# Altium schematic sheet Pitch CV & Tune Depth.SchDoc("Pitch CV & Tune Depth")
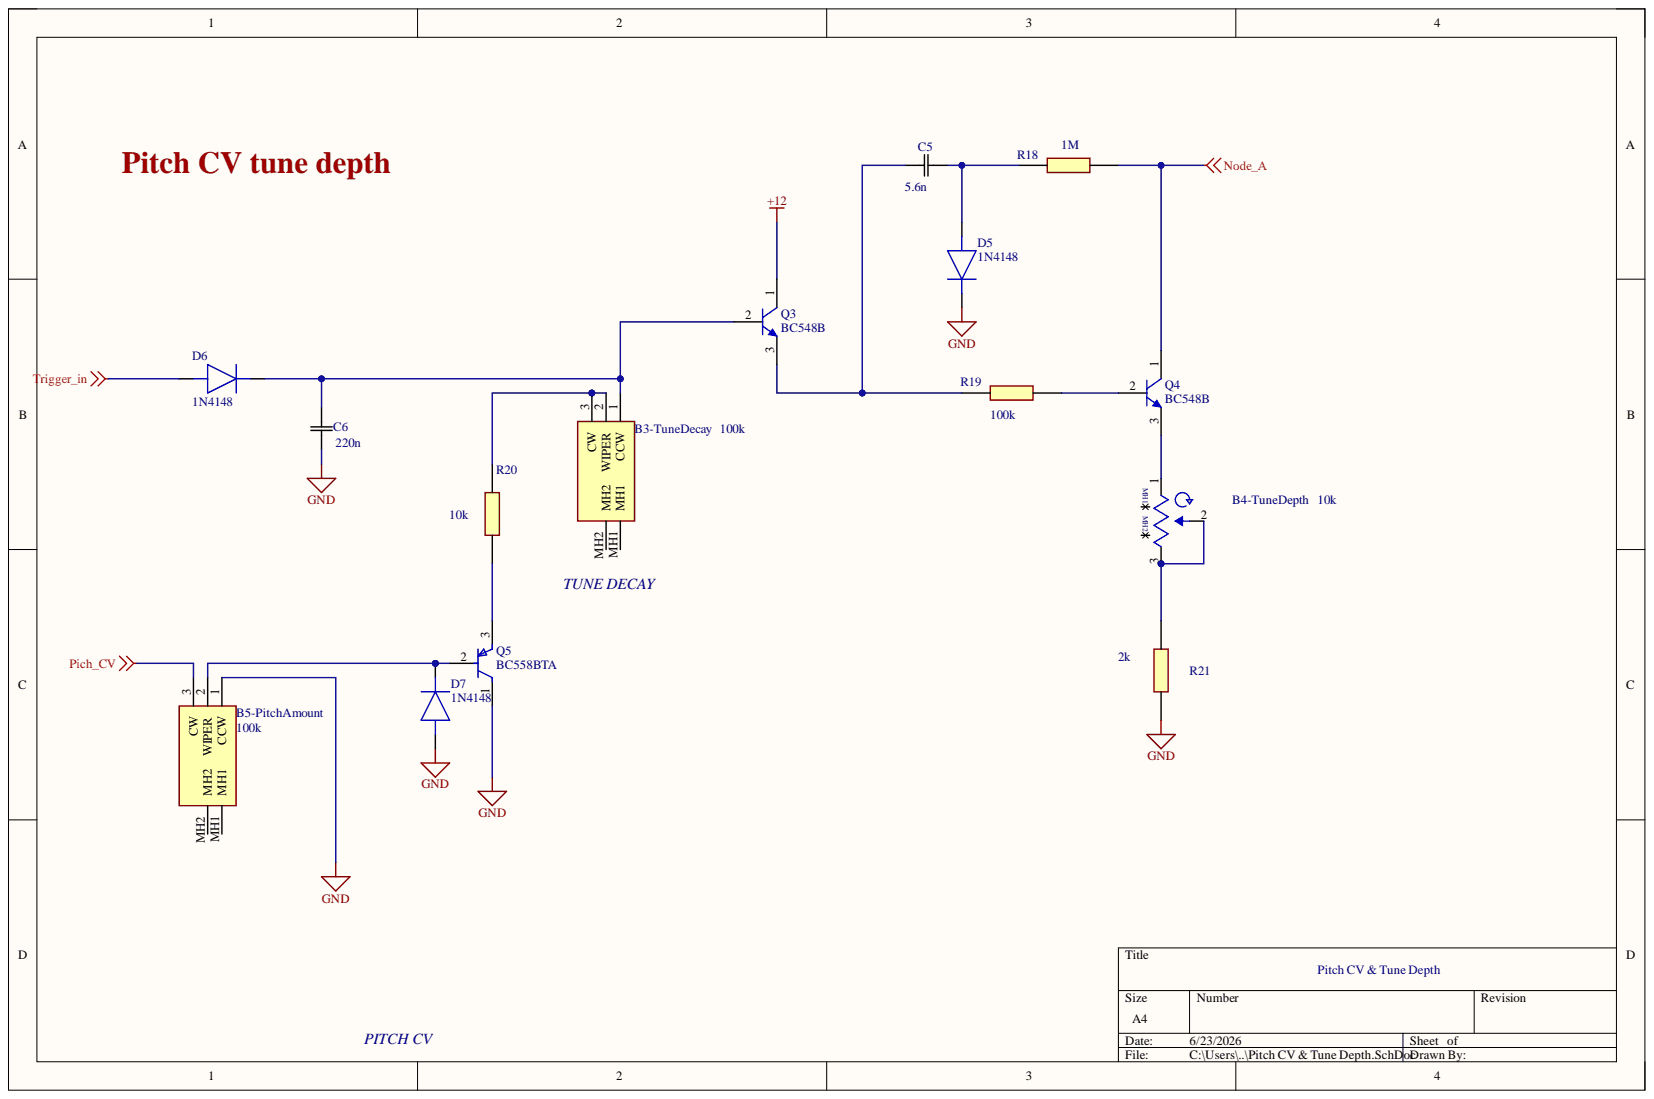
[diagram p0: Pitch CV & Tune Depth.SchDoc]

## Parts
B3-TuneDecay  value 100k  [PTV09A4025FB104]  (hidden comment: PTV09A-4025F-B104)
B4-TuneDepth  value 10k  [PTV09A4025FB103]  (hidden comment: PTV09A-4025F-B103)
B5-PitchAmount  value 100k  [PTV09A4025FB104]  (hidden comment: PTV09A-4025F-B104)
C5  value 5.6n  [CAP_MMK5_122_J100_KEM]  (hidden comment: MMK5332K50J01L4BULK)
C6  value 220n  [CAP_MMK5_122_J100_KEM-1]  (hidden comment: MMK5224J50J01L4BULK)
D5  1N4148  [DIOAD1068W53L380D172]
D6  1N4148  [DIOAD1068W53L380D172]
D7  1N4148  [DIOAD1068W53L380D172]
Q3  BC548B  [ONSC-TO-92-3-29-11]
Q4  BC548B  [ONSC-TO-92-3-29-11]
Q5  BC558BTA  [FP-135AR-MFG]
R18  value 1M  [RESAD1590W60L630D240]  (hidden comment: MFR-25FTF52-600K)
R19  value 100k  [RESAD1590W60L630D240]  (hidden comment: MFR-25FTF52-600K)
R20  value 10k  [RESAD1590W60L630D240]  (hidden comment: MFR-25FTF52-600K)
R21  value 2k  [RESAD1590W60L630D240]  (hidden comment: MFR-25FTF52-600K)

## Nets
+12: Q3.1
GND: B5-PitchAmount.1(CCW) C6.1 D5.1 D7.2 Q5.1 R21.2
Node_A: Q4.1 R18.2
Pich_CV: B5-PitchAmount.3(CW)
Trigger_in: D6.2
NetB3-TuneDecay_1: B3-TuneDecay.1(CCW) C6.2 D6.1 Q3.2
NetB3-TuneDecay_2: B3-TuneDecay.2(WIPER) B3-TuneDecay.3(CW) R20.1
NetB4-TuneDepth_1: B4-TuneDepth.1 Q4.3
NetB4-TuneDepth_2: B4-TuneDepth.2 B4-TuneDepth.3 R21.1
NetB5-PitchAmount_2: B5-PitchAmount.2(WIPER) D7.1 Q5.2
NetC5_1: C5.1 Q3.3 R19.1
NetC5_2: C5.2 D5.2 R18.1
NetQ4_2: Q4.2 R19.2
NetQ5_3: Q5.3 R20.2

Not connected: B3-TuneDecay MH1 MH2; B4-TuneDepth 4 5; B5-PitchAmount MH1 MH2

## Library data of the parts
B3-TuneDecay B5-PitchAmount = CMP-032-000030-1: Variable Resistor; Manufacturer_Name: Bourns; Arrow Part Number: PTV09A-4025F-B104; Arrow Price/Stock: https://www.arrow.com/en/products/ptv09a-4025f-b104/bourns?region=nac; Component Kind: Standard; Datasheet Link: https://www.digikey.ph/en/products/detail/bourns-inc/PTV09A-4025F-B104/3820577?msockid=39f1ec84d77b6f4428b7f904d6676eed; Design Item ID: PTV09A-4025F-B104; Height: 25.5mm; Manufacturer_Part_Number: PTV09A-4025F-B104; Mouser Part Number: 652-PTV09A4025FB104; Mouser Price/Stock: https://www.mouser.co.uk/ProductDetail/Bourns/PTV09A-4025F-B104?qs=fgHA1UgJI8DoC1GZkaXClg%3D%3D; Source: PTV0910k.SchLib; Library Name: PoliTeK
B4-TuneDepth = CMP-011-000000-2: Variable Resistor; Manufacturer_Name: Bourns; Arrow Part Number: PTV09A-4025F-B103; Arrow Price/Stock: null?region=nac; Component Kind: Standard; Component Type: Standard; Datasheet Link: https://www.bourns.com/docs/Product-Datasheets/PTV09.pdf; Design Item ID: PTV09A-4025F-B103; Height: 25.5mm; Manufacturer_Part_Number: PTV09A-4025F-B103; Mouser Part Number: 652-PTV09A-4025FB103; Mouser Price/Stock: https://www.mouser.co.uk/ProductDetail/Bourns/PTV09A-4025F-B103?qs=okKRLHKnSlbwMbAO07mw0g%3D%3D; Pin Count: 5; Source: PTV0910k.SchLib; Library Name: EMEA - Politecnico di Torino - PoliTek Music
C5 = CMP-001-00099-1: 3.3 nF ceramic capacitor-; Component Kind: Standard; Component Type: Standard; Design Item ID: MMK5332K50J01L4BULK; Pins: 2; Source: MMK5332K50J01L4BULK.SchLib; Library Name: EMEA - Politecnico di Torino - PoliTek Music
C6 = CMP-001-00098-2: 220 nF film capacitor; Component Kind: Standard; Component Type: Standard; Design Item ID: MMK5224J50J01L4BULK; Pins: 2; Source: MMK5224J50J01L4BULK.SchLib; Library Name: EMEA - Politecnico di Torino - PoliTek Music
D5-D7 = CMP-004-00032-1: Small Signal Diode; Manufacturer_Name: onsemi; Arrow Part Number: 1N4148; Arrow Price/Stock: https://www.arrow.com/en/products/1n4148/on-semiconductor?region=europe; Component Kind: Standard; Component Type: Standard; Datasheet Link: https://www.onsemi.com/pub/Collateral/1N914-D.PDF; Design Item ID: 1N4148; Manufacturer_Part_Number: 1N4148; Mouser Part Number: 512-1N4148; Mouser Price/Stock: https://www.mouser.co.uk/ProductDetail/onsemi-Fairchild/1N4148?qs=i4Fj9T%2FoRm8RMUhj5DeFQg%3D%3D; Pins: 2; Source: SamacSys.SchLib; Library Name: EMEA - Politecnico di Torino - PoliTek Music
Q3 Q4 = CMP-013-00036-5: Bulk Through Hole NPN Single Bipolar (BJT) Transistor 200 @ 2mA 5V 100mA 500mW 300MHz; Case/Package: TO-92; Component Kind: Standard; Frequency: 300MHz; Max Operating Temperature: 150°C; Min Operating Temperature: -55°C; Mounting Technology: Through Hole; Pins: 3; Library Name: EMEA - Politecnico di Torino - PoliTek Music
Q5 = CMP-00278-84408946-1: BC558BTA Small Signal Bipolar Transistor, 0.1A I(C), 30V V(BR)CEO, 1-Element, PNP, Silicon, TO-92; Collector Base Voltage (VCBO): -30V; Collector Emitter Saturation Voltage: -250mV; Current Rating: -100mA; Element Configuration: Single; Emitter Base Voltage (VEBO): -5V; Frequency: 150MHz; hFE Min: 110; Max Frequency: 10MHz; Max Junction Temperature (Tj): 150°C; Max Power Dissipation: 500mW; Number of Elements: 1; Case/Package: TO-92; Collector Emitter Breakdown Voltage: 30V; Collector Emitter Voltage (VCEO): -30V; Component Kind: Standard; Contact Plating: Tin; Gain Bandwidth Product: 150MHz; Height: 5.33mm; Lead Free: Lead Free; Length: 5.2mm; Library Name: Altium Content Vault; Max Breakdown Voltage: 30V; Max Collector Current: -100mA; Max Operating Temperature: 150°C; Min Operating Temperature: -65°C; Mount: Through Hole; NexarPartId: 84408946; Number of Pins: 3; Polarity: PNP; Power Dissipation: 500mW; REACH SVHC: No SVHC; RoHS: Compliant; Schedule B: 8541210080; Transition Frequency: 150MHz; Voltage Rating (DC): -30V; Weight: 240mg; Width: 4.19mm
R18-R21 = CMP-009-00182-2: Metal Film Resistors - Through Hole; Manufacturer_Name: YAGEO; Component Kind: Standard; Component Type: Standard; Datasheet Link: https://www.mouser.sg/datasheet/2/447/YAGEO_MFR_datasheet_2023v3-3324391.pdf; Design Item ID: MFR-25FTF52-600K; Manufacturer_Part_Number: MFR-25FTF52-600K; Mouser Part Number: 603-MFR-25FTF52-600K; Mouser Price/Stock: https://www.mouser.co.uk/ProductDetail/YAGEO/MFR-25FTF52-600K?qs=xZ%2FP%252Ba9zWqYtTZdHR75SUg%3D%3D; Pins: 2; Source: SamacSys.SchLib; Library Name: EMEA - Politecnico di Torino - PoliTek Music
